# Altium schematic sheet 1.Engine.SchDoc
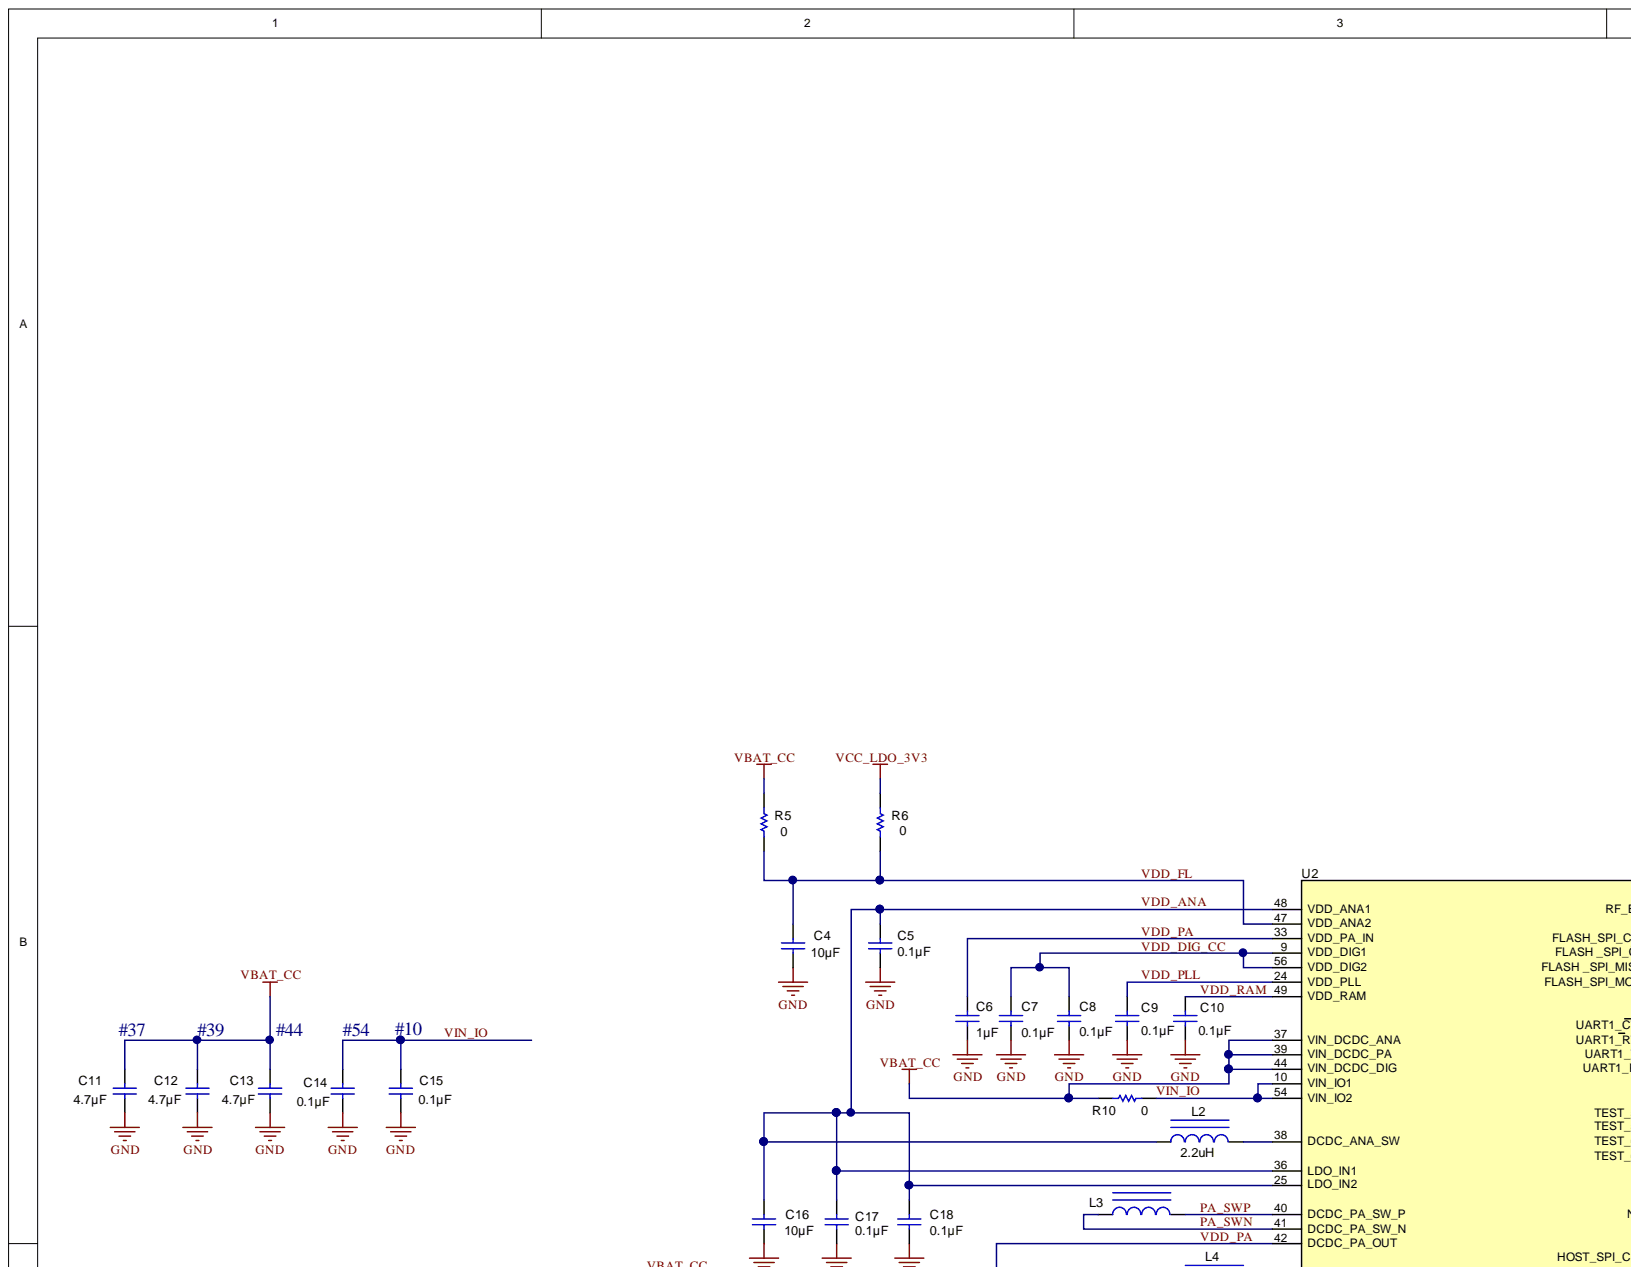
[diagram p0: 1.Engine.SchDoc, top left]
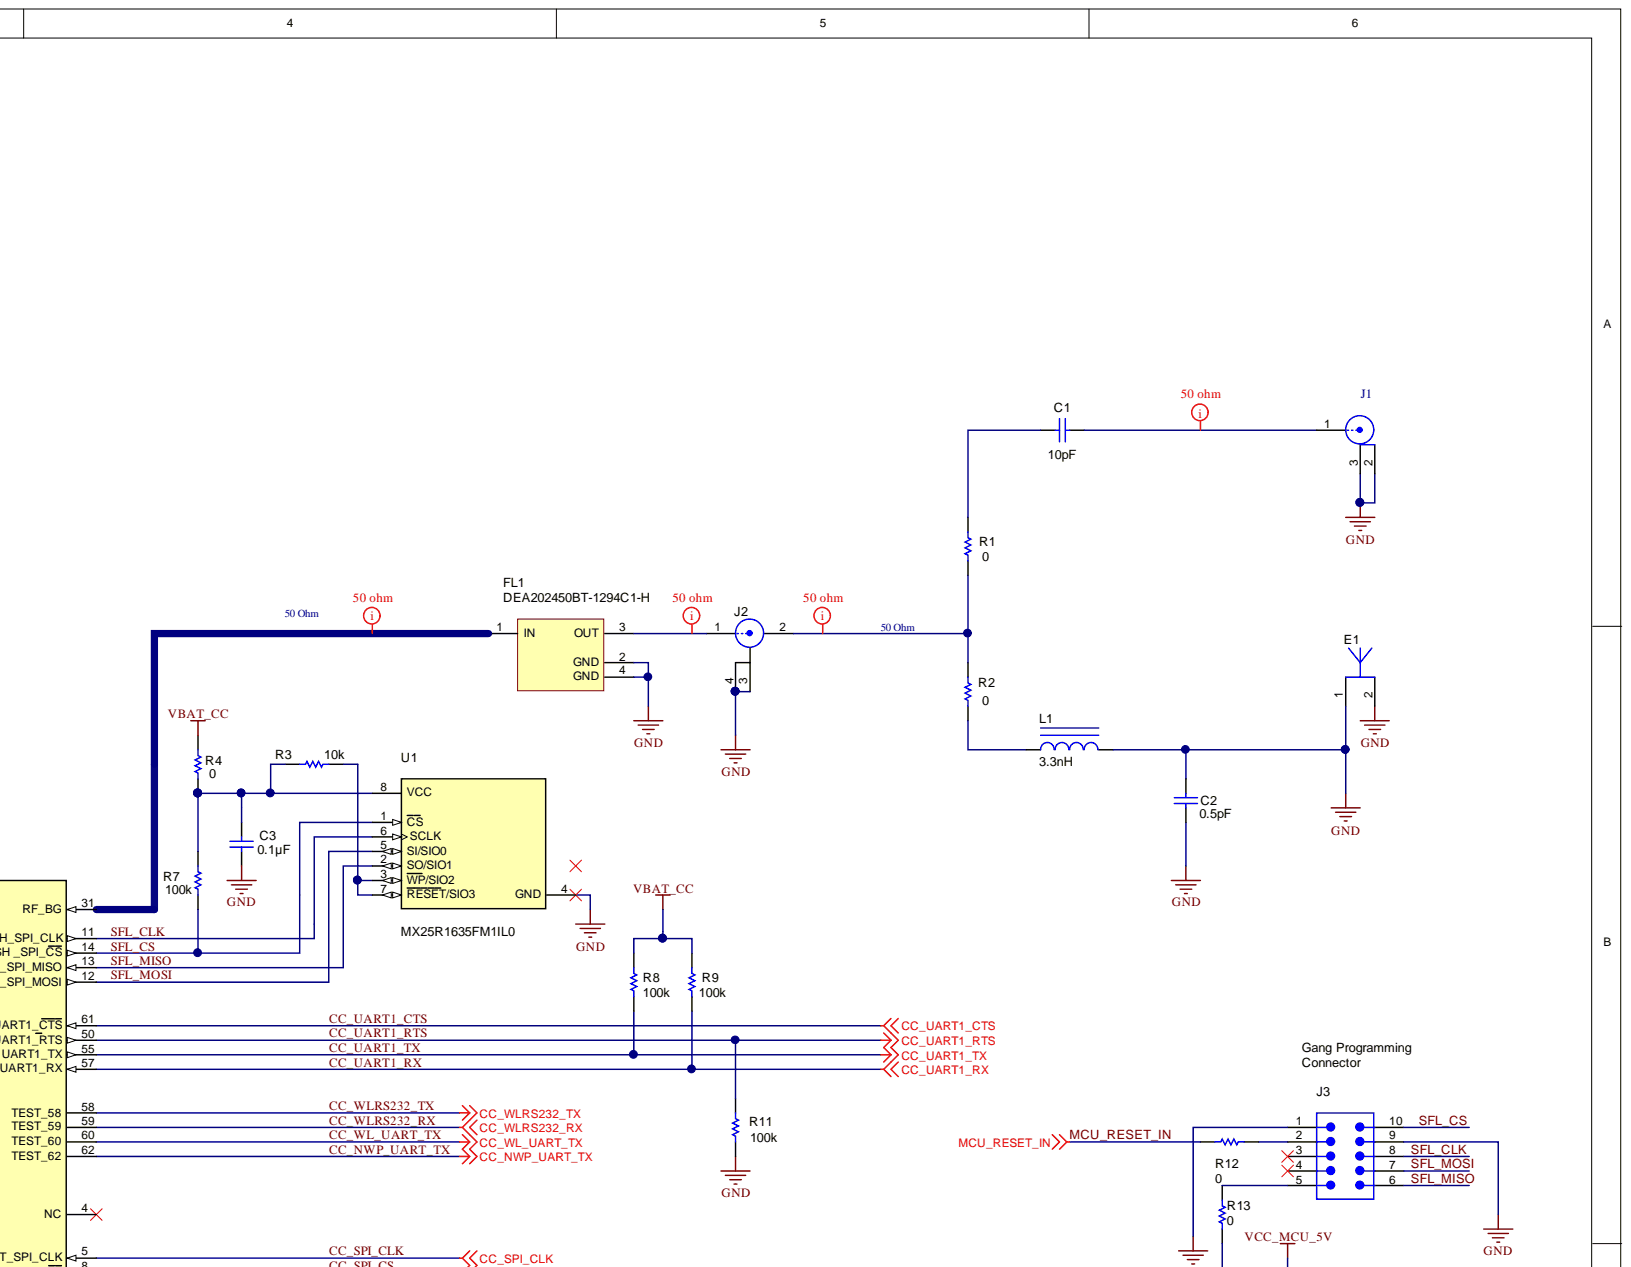
[diagram p1: 1.Engine.SchDoc, top right]
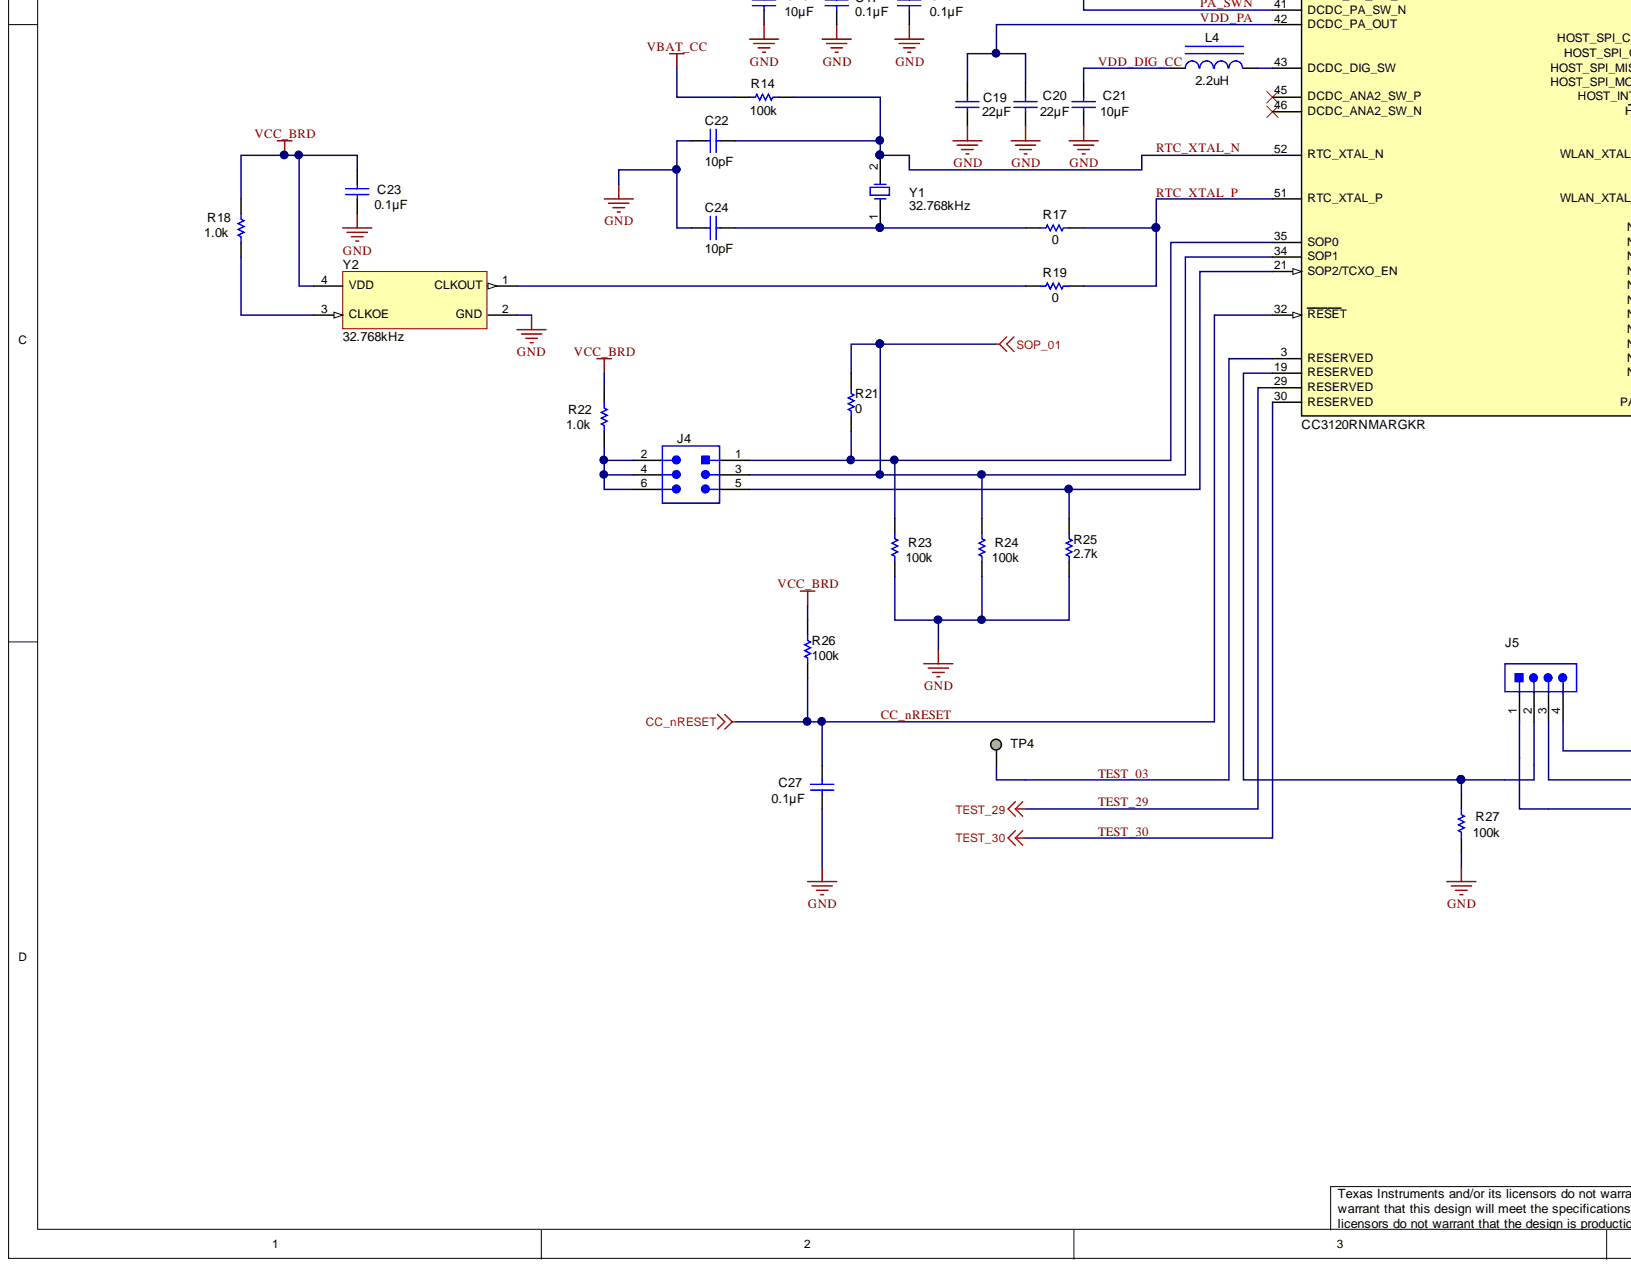
[diagram p2: 1.Engine.SchDoc, bottom left]
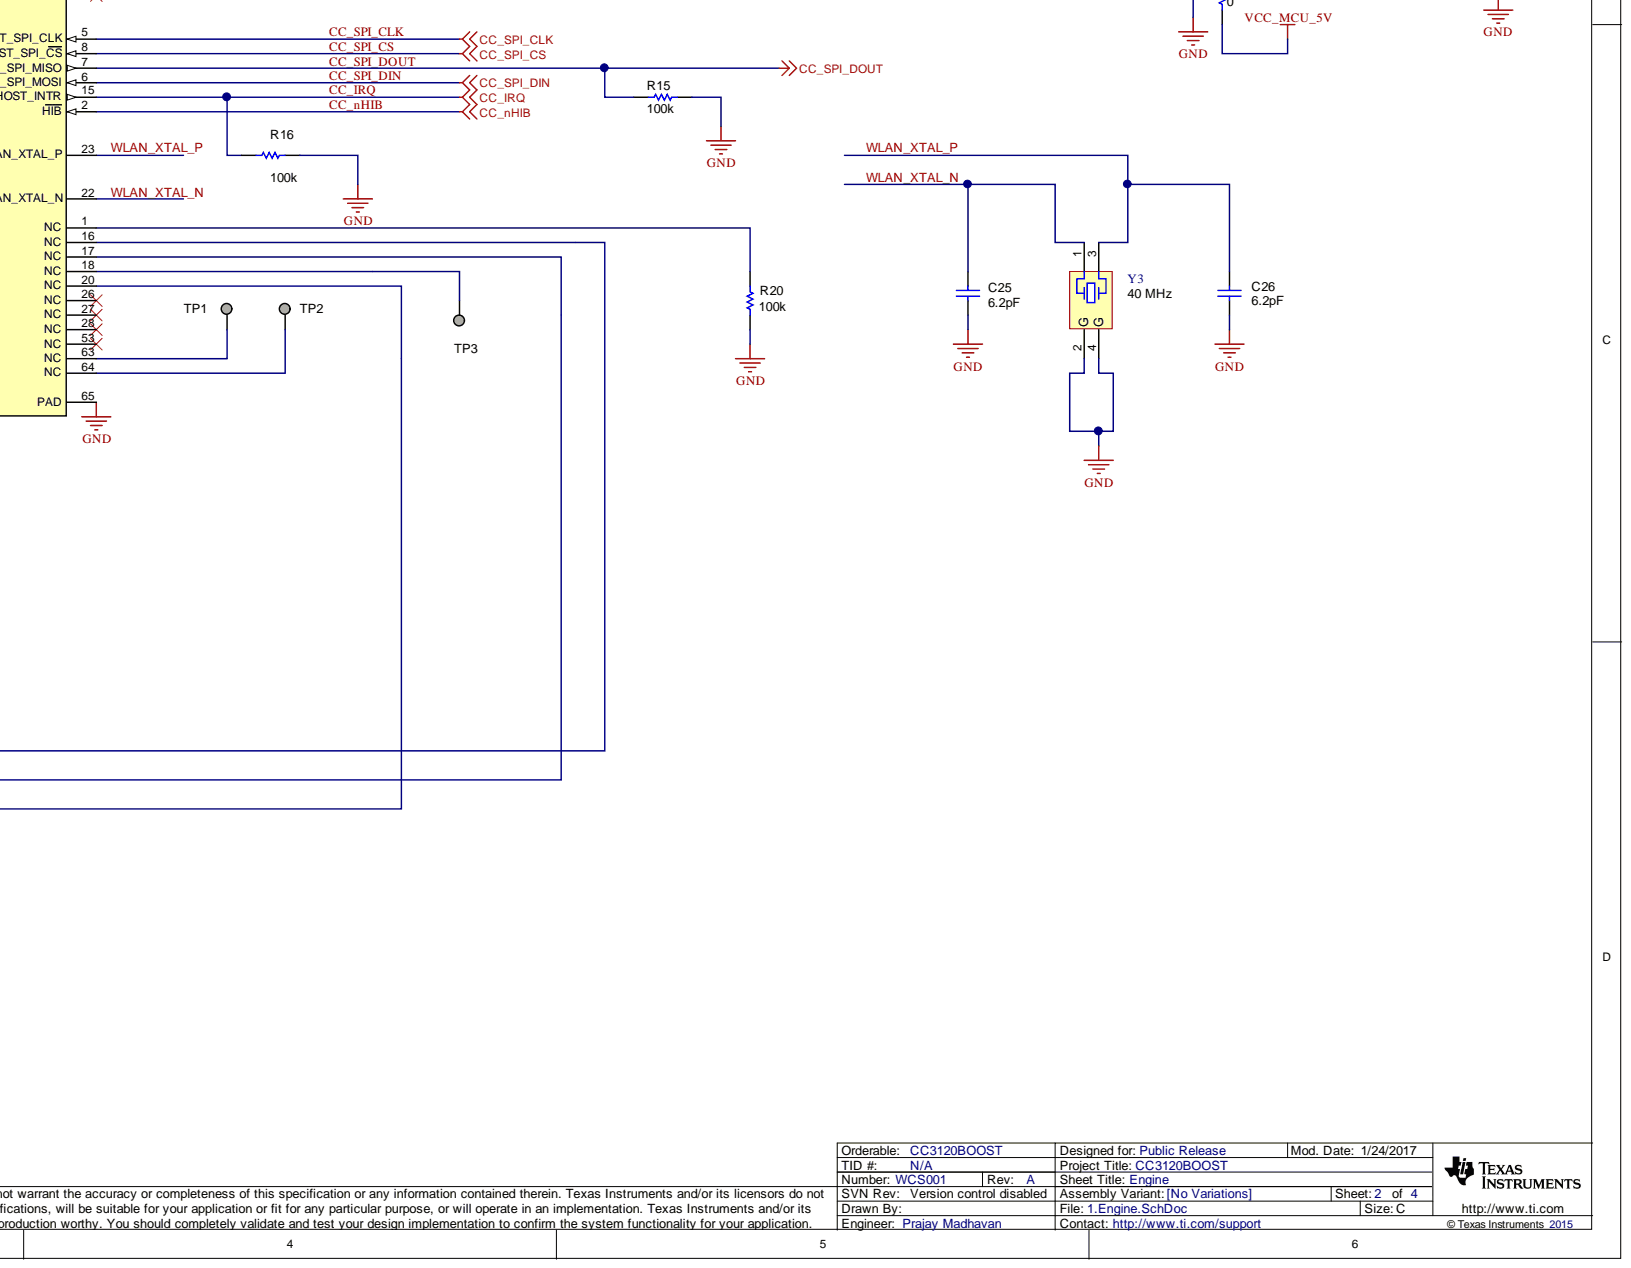
[diagram p3: 1.Engine.SchDoc, bottom right]

## Parts
C1  value 10pF  [0402]  (hidden comment: 500R07S100JV4T)
C2  value 0.5pF  [0402]  (hidden comment: GRM1555C1HR50BA01D)
C3  ValueDisplayed: 0.1µF  [0402]  (hidden comment: C1005X5R1A104K050BA)
C4  ValueDisplayed: 10µF  [0603]  (hidden comment: GRM188R60J106ME47D)
C5  ValueDisplayed: 0.1µF  [0402]  (hidden comment: C1005X5R1A104K050BA)
C6  ValueDisplayed: 1µF  [0402]  (hidden comment: C1005X5R1A105K050BB)
C7  ValueDisplayed: 0.1µF  [0402]  (hidden comment: C1005X5R1A104K050BA)
C8  ValueDisplayed: 0.1µF  [0402]  (hidden comment: C1005X5R1A104K050BA)
C9  ValueDisplayed: 0.1µF  [0402]  (hidden comment: C1005X5R1A104K050BA)
C10  ValueDisplayed: 0.1µF  [0402]  (hidden comment: C1005X5R1A104K050BA)
C11  ValueDisplayed: 4.7µF  [0402]  (hidden comment: C1005X5R0J475M050BC)
C12  ValueDisplayed: 4.7µF  [0402]  (hidden comment: C1005X5R0J475M050BC)
C13  ValueDisplayed: 4.7µF  [0402]  (hidden comment: C1005X5R0J475M050BC)
C14  ValueDisplayed: 0.1µF  [0402]  (hidden comment: C1005X5R1A104K050BA)
C15  ValueDisplayed: 0.1µF  [0402]  (hidden comment: C1005X5R1A104K050BA)
C16  ValueDisplayed: 10µF  [0603]  (hidden comment: GRM188R60J106ME47D)
C17  ValueDisplayed: 0.1µF  [0402]  (hidden comment: C1005X5R1A104K050BA)
C18  ValueDisplayed: 0.1µF  [0402]  (hidden comment: C1005X5R1A104K050BA)
C19  ValueDisplayed: 22µF  [0603]  (hidden comment: C1608X5R0G226M080AA)
C20  ValueDisplayed: 22µF  [0603]  (hidden comment: C1608X5R0G226M080AA)
C21  ValueDisplayed: 10µF  [0603]  (hidden comment: GRM188R60J106ME47D)
C22  value 10pF  [0402]  (hidden comment: 500R07S100JV4T)
C23  ValueDisplayed: 0.1µF  [0402]  (hidden comment: C1005X5R1A104K050BA)
C24  value 10pF  [0402]  (hidden comment: 500R07S100JV4T)
C25  value 6.2pF  [0402]  (hidden comment: GRM1555C1H6R2CA01D)
C26  value 6.2pF  [0402]  (hidden comment: GRM1555C1H6R2CA01D)
C27  ValueDisplayed: 0.1µF  [0402]  (hidden comment: C1005X5R1A104K050BA)
E1  AH316M245001-T  [TAYO_AH316M]  (value not drawn)
FL1  DEA202450BT-1294C1-H  [TDK_DEA202450BT-1294C1-H]
J1  U.FL-R-SMT-1  [CONN_UFL-R-SMT-1]  (value not drawn)
J2  MM8030-2610RJ3  [MuRata_MM8030-2610]  (value not drawn)
J3  TC2050-IDC-430-FP  [TC2050-IDC-430-FP]  (value not drawn)  (no BOM)
J4  GRPB032VWVN-RC  [Sullins_GRPB032VWVN-RC]  (value not drawn)
J5  GRPB041VWVN-RC  [Sullins_GRPB041VWVN-RC]  (value not drawn)
L1  3.3nH  [IND_LQG15H]
L2  value 2.2uH  [1008]  (hidden comment: LQM2HPN2R2MG0L)
L3  LQM2HPN1R0MG0L  [1008]  (value not drawn)
L4  value 2.2uH  [1008]  (hidden comment: LQM2HPN2R2MG0L)
R1  value 0  [0402]  (hidden comment: ERJ-2GE0R00X)
R2  value 0  [0402]  (hidden comment: ERJ-2GE0R00X)
R3  value 10k  [0402]  (hidden comment: CRCW040210K0JNED)
R4  value 0  [0603]  (hidden comment: RC0603JR-070RL)
R5  value 0  [0603]  (hidden comment: RC0603JR-070RL)
R6  value 0  [0603]  (hidden comment: RC0603JR-070RL)
R7  value 100k  [0402]  (hidden comment: CRCW0402100KJNED)
R8  value 100k  [0402]  (hidden comment: CRCW0402100KJNED)
R9  value 100k  [0402]  (hidden comment: CRCW0402100KJNED)
R10  value 0  [0603]  (hidden comment: RC0603JR-070RL)
R11  value 100k  [0402]  (hidden comment: CRCW0402100KJNED)
R12  value 0  [0402]  (hidden comment: ERJ-2GE0R00X)
R13  value 0  [0402]  (hidden comment: ERJ-2GE0R00X)
R14  value 100k  [0402]  (hidden comment: CRCW0402100KJNED)
R15  value 100k  [0402]  (hidden comment: CRCW0402100KJNED)
R16  value 100k  [0402]  (hidden comment: CRCW0402100KJNED)
R17  value 0  [0402]  (hidden comment: ERJ-2GE0R00X)
R18  value 1.0k  [0402]  (hidden comment: CRCW04021K00JNED)
R19  value 0  [0402]  (hidden comment: ERJ-2GE0R00X)
R20  value 100k  [0402]  (hidden comment: CRCW0402100KJNED)
R21  value 0  [0402]  (hidden comment: ERJ-2GE0R00X)
R22  value 1.0k  [0402]  (hidden comment: CRCW04021K00JNED)
R23  value 100k  [0402]  (hidden comment: CRCW0402100KJNED)
R24  value 100k  [0402]  (hidden comment: CRCW0402100KJNED)
R25  value 2.7k  [0402]  (hidden comment: CRCW04022K70JNED)
R26  value 100k  [0402]  (hidden comment: CRCW0402100KJNED)
R27  value 100k  [0402]  (hidden comment: CRCW0402100KJNED)
TP1  TESTPOINT  [TP_H045P075]  (value not drawn)  (no BOM)
TP2  TESTPOINT  [TP_H045P075]  (value not drawn)  (no BOM)
TP3  TESTPOINT  [TP_H045P075]  (value not drawn)  (no BOM)
TP4  TESTPOINT  [TP_H045P075]  (value not drawn)  (no BOM)
U1  MX25R1635FM1IL0  [SOP-8_150MIL]
U2  CC3120RNMARGKR  [RGK0064B]
Y1  Frequency: 32.768kHz  [XTAL_ABS07]  (hidden comment: ABS07-32.768KHZ-9-T)
Y2  Frequency: 32.768kHz  [MC_OV-7604-C7]  (hidden comment: OV-7604-C7)
Y3  Frequency: 40 MHz  [Epson_FA-20H]  (hidden comment: Q24FA20H0039600)

## Nets
GND: C2.1 C2.2 C3.2 C4.2 C5.2 C6.2 C7.2 C8.2 C9.2 C10.2 C11.2 C12.2 C13.2 C14.2 C15.2 C16.2 C17.2 C18.2 C19.2 C20.2 C21.2 C22.2 C23.2 C24.2 C25.2 C26.2 C27.2 E1.1 E1.2 FL1.2(GND) FL1.4(GND) J1.2 J1.3 J2.3 J2.4 J3.1 J3.9 L1.2 R11.2 R15.2 R16.2 R20.2 R23.2 R24.2 R25.2 R27.2 U1.4(GND) U2.65(PAD) Y2.2(GND) Y3.2(G) Y3.4(G)
SOP_01: J4.3 R21.2 R24.1 U2.34(SOP1)
VBAT_CC: C11.1 C12.1 C13.1 R4.2 R5.2 R8.1 R9.1 R10.2 R14.1 U2.37(VIN_DCDC_ANA) U2.39(VIN_DCDC_PA) U2.44(VIN_DCDC_DIG)
VCC_BRD: C23.1 R18.1 R22.1 R26.1 Y2.4(VDD)
VCC_LDO_3V3: R6.2
VCC_MCU_5V: R13.1
CC_IRQ: R16.1 U2.15(HOST_INTR)
CC_NHIB: U2.2(HIB)
CC_NRESET: C27.1 R26.2 U2.32(RESET)
CC_NWP_UART_TX: U2.62(TEST_62)
CC_SPI_CLK: U2.5(HOST_SPI_CLK)
CC_SPI_CS: U2.8(HOST_SPI_CS)
CC_SPI_DIN: U2.6(HOST_SPI_MOSI)
CC_SPI_DOUT: R15.1 U2.7(HOST_SPI_MISO)
CC_UART1_CTS: U2.61(UART1_CTS)
CC_UART1_RTS: R11.1 U2.50(UART1_RTS)
CC_UART1_RX: R9.2 U2.57(UART1_RX)
CC_UART1_TX: R8.2 U2.55(UART1_TX)
CC_WL_UART_TX: U2.60(TEST_60)
CC_WLRS232_RX: U2.59(TEST_59)
CC_WLRS232_TX: U2.58(TEST_58)
MCU_RESET_IN: R12.2
PA_SWN: L3.1 U2.41(DCDC_PA_SW_N)
PA_SWP: L3.2 U2.40(DCDC_PA_SW_P)
RTC_XTAL_N: C22.1 R14.2 U2.52(RTC_XTAL_N) Y1.2
RTC_XTAL_P: R17.2 R19.2 U2.51(RTC_XTAL_P)
SFL_CLK: J3.8 U1.6(SCLK) U2.11(FLASH_SPI_CLK)
SFL_CS: J3.10 R7.2 U1.1(CS) U2.14(FLASH__SPI_CS)
SFL_MISO: J3.6 U1.2(SO/SIO1) U2.13(FLASH__SPI_MISO)
SFL_MOSI: J3.7 U1.5(SI/SIO0) U2.12(FLASH_SPI_MOSI)
TEST_03: TP4.1 U2.3(RESERVED)
TEST_29: U2.29(RESERVED)
TEST_30: U2.30(RESERVED)
VDD_ANA: C5.1 C16.1 C17.1 C18.1 L2.1 U2.25(LDO_IN2) U2.36(LDO_IN1) U2.48(VDD_ANA1)
VDD_DIG_CC: C7.1 C8.1 C21.1 L4.1 U2.9(VDD_DIG1) U2.56(VDD_DIG2)
VDD_FL: C4.1 R5.1 R6.1 U2.47(VDD_ANA2)
VDD_PA: C6.1 C19.1 C20.1 U2.33(VDD_PA_IN) U2.42(DCDC_PA_OUT)
VDD_PLL: C9.1 U2.24(VDD_PLL)
VDD_RAM: C10.1 U2.49(VDD_RAM)
VIN_IO: C14.1 C15.1 R10.1 U2.10(VIN_IO1) U2.54(VIN_IO2)
WLAN_XTAL_N: C25.1 U2.22(WLAN_XTAL_N) Y3.1
WLAN_XTAL_P: C26.1 U2.23(WLAN_XTAL_P) Y3.3
NetC1_1: C1.1 R1.2
NetC1_2: C1.2 J1.1
NetC3_1: C3.1 R3.1 R4.1 R7.1 U1.8(VCC)
NetC24_1: C24.1 R17.1 Y1.1
NetFL1_1: FL1.1(IN) U2.31(RF_BG)
NetFL1_3: FL1.3(OUT) J2.1
NetJ2_2: J2.2 R1.1 R2.2
NetJ3_2: J3.2 R12.1
NetJ3_5: J3.5 R13.2
NetJ4_1: J4.1 R21.1 R23.1 U2.35(SOP0)
NetJ4_2: J4.2 J4.4 J4.6 R22.2
NetJ4_5: J4.5 R25.1 U2.21(SOP2/TCXO_EN)
NetJ5_1: J5.1 U2.20(NC)
NetJ5_2: J5.2 R27.1 U2.19(RESERVED)
NetJ5_3: J5.3 U2.17(NC)
NetJ5_4: J5.4 U2.16(NC)
NetL1_1: L1.1 R2.1
NetL2_2: L2.2 U2.38(DCDC_ANA_SW)
NetL4_2: L4.2 U2.43(DCDC_DIG_SW)
NetR3_2: R3.2 U1.3(WP/SIO2) U1.7(RESET/SIO3)
NetR18_2: R18.2 Y2.3(CLKOE)
NetR19_1: R19.1 Y2.1(CLKOUT)
NetR20_1: R20.1 U2.1(NC)
NetTP1_1: TP1.1 U2.63(NC)
NetTP2_1: TP2.1 U2.64(NC)
NetTP3_1: TP3.1 U2.18(NC)

Not connected: J3 3 4; U2 4(NC) 26(NC) 27(NC) 28(NC) 45(DCDC_ANA2_SW_P) 46(DCDC_ANA2_SW_N) 53(NC)

## Library data of the parts
C1 C22 C24 = 500R07S100JV4T: CAP, CERM, 10 pF, 50 V, +/- 5%, C0G/NP0, 0402; Manufacturer: Johanson Technology; Cap_F: 0.00000000001; CompType: Capacitor; Polarized: N; TaMax_C: 125; TaMin_C: -55; Tolerance_%: 5; U/M: EA; Value1: Unknown; Value2: 50V; VDC_V: 50; Cap_Case: 0402; Component Kind: Standard; ComponentLink1Description: Datasheet link; ComponentLink1URL: http://johansontechnology.com/images/stories/rfcaps/mlhqcaps/JTI_MLCC_HighQ_2011_14.pdf; LatestRevisionDate: 2015-02-23; Library Name: Capacitor_Ceramic.IntLib; MountType: SMT; PackageReference: 0402; PartNumber: 500R07S100JV4T; Published: 2008-06-19; RoHS: Y; Signal Integrity: Cap; Simulation: Cap; Supplier 1: Digi-Key; Supplier 2: Farnell; Supplier 3: Newark; Supplier Part Number 1: 712-1253-1-ND; Supplier Part Number 2: 1885333; Supplier Part Number 3: 97M6183; SymbolVersion: 2008-07-09; Technology: Ceramic; TempCo: C0G/NP0; ValueDisplayed: 10pF; Publisher: Texas Instruments
C2 = GRM1555C1HR50BA01D: CAP, CERM, 0.5 pF, 50 V, +/- 20%, C0G/NP0, 0402; Manufacturer: MuRata; Cap_F: 0.0000000000005; CompType: Capacitor; Polarized: N; TaMax_C: 125; TaMin_C: -55; Tolerance_%: 20; U/M: EA; Value1: Unknown; Value2: 50V; VDC_V: 50; Cap_Case: 0402; Component Kind: Standard; ComponentLink1Description: Datasheet link; ComponentLink1URL: http://www.murata.com/~/media/webrenewal/support/library/catalog/products/capacitor/mlcc/c02e.ashx; LatestRevisionDate: 2015-04-02; Library Name: Capacitor_Ceramic.IntLib; MountType: SMT; PackageReference: 0402; PartNumber: GRM1555C1HR50BA01D; Published: 2008-06-19; RoHS: Y; Signal Integrity: Cap; Simulation: Cap; Supplier 1: Digi-Key; Supplier 2: Mouser; Supplier Part Number 1: 490-6263-1-ND; Supplier Part Number 2: 81-GRM1555C1HR50BA1D; SymbolVersion: 2008-07-09; Technology: Ceramic; TempCo: C0G/NP0; ValueDisplayed: 0.5pF; Publisher: Texas Instruments
C3 C5 C7-C10 C14 C15 C17 C18 C23 C27 = C1005X5R1A104K050BA: CAP, CERM, 0.1 µF, 10 V, +/- 10%, X5R, 0402; Manufacturer: TDK; Cap_F: 0.0000001; CompType: Capacitor; Polarized: N; TaMax_C: 85; TaMin_C: -55; Tolerance_%: 10; U/M: EA; Value: 0.1uF; Value1: Unknown; Value2: 10V; Cap_Case: 0402; Component Kind: Standard; ComponentLink1Description: Datasheet link; ComponentLink1URL: http://product.tdk.com/en/catalog/datasheets/mlcc_commercial_general_en.pdf; LatestRevisionDate: 2015-02-23; Library Name: Capacitor_Ceramic.IntLib; MountType: SMT; PackageReference: 0402; PartNumber: C1005X5R1A104K050BA; Published: 2008-06-19; RoHS: Y; Signal Integrity: Cap; Simulation: Cap; Supplier 1: Digi-Key; Supplier 2: Mouser; Supplier 3: Farnell; Supplier Part Number 1: 445-1265-1-ND; Supplier Part Number 2: 810-C1005X5R1A104K; Supplier Part Number 3: 2368215; SymbolVersion: 2008-07-09; Technology: Ceramic; TempCo: X5R; VDC_V: 10; Publisher: Texas Instruments
C4 C16 C21 = GRM188R60J106ME47D: CAP, CERM, 10 µF, 6.3 V, +/- 20%, X5R, 0603; Manufacturer: MuRata; Cap_F: 0.00001; CompType: Capacitor; ESR_ohm: 0.009; IRMS_A: 2.74; Polarized: N; TaMax_C: 125; TaMin_C: -55; Tolerance_%: 20; U/M: EA; Value: 10uF; Value1: 0.009 ohm; Value2: 6.3V; VDC_V: 6.3; Cap_Case: 0603; Component Kind: Standard; ComponentLink1Description: Datasheet link; ComponentLink1URL: http://www.murata.com/products/capacitor/tech_data/pdf/grm188r60j106m.pdf; LatestRevisionDate: 2015-02-23; Library Name: Capacitor_Ceramic.IntLib; MountType: SMT; PackageReference: 0603; PartNumber: GRM188R60J106ME47D; Published: 2008-06-19; RoHS: Y; Signal Integrity: Cap; Simulation: Cap; Supplier 1: Digi-Key; Supplier 2: Mouser; Supplier 3: Farnell; Supplier Part Number 1: 490-3896-1-ND; Supplier Part Number 2: 81-GRM188R60J106ME47; Supplier Part Number 3: 1735528; SymbolVersion: 2008-07-09; Technology: Ceramic; TempCo: X5R; Publisher: Texas Instruments
C6 = C1005X5R1A105K050BB: CAP, CERM, 1 µF, 10 V, +/- 10%, X5R, 0402; Manufacturer: TDK; Cap_F: 0.000001; CompType: Capacitor; Polarized: N; TaMax_C: 85; TaMin_C: -55; Tolerance_%: 10; U/M: EA; Value: 1uF; Value1: Unknown; Value2: 10V; Cap_Case: 0402; Component Kind: Standard; ComponentLink1Description: Datasheet link; ComponentLink1URL: http://product.tdk.com/en/catalog/datasheets/mlcc_commercial_general_en.pdf; LatestRevisionDate: 2015-02-23; Library Name: Capacitor_Ceramic.IntLib; MountType: SMT; PackageReference: 0402; PartNumber: C1005X5R1A105K050BB; Published: 2008-06-19; RoHS: Y; Signal Integrity: Cap; Simulation: Cap; Supplier 1: Digi-Key; Supplier 2: Mouser; Supplier 3: Farnell; Supplier Part Number 1: 445-4114-1-ND; Supplier Part Number 2: 810-C1005X5R1A105K; Supplier Part Number 3: 2371912; SymbolVersion: 2008-07-09; Technology: Ceramic; TempCo: X5R; VDC_V: 10; Publisher: Texas Instruments
C11-C13 = C1005X5R0J475M050BC: CAP, CERM, 4.7 µF, 6.3 V, +/- 20%, X5R, 0402; Manufacturer: TDK; Cap_F: 0.0000047; CompType: Capacitor; Polarized: N; TaMax_C: 85; TaMin_C: -55; Tolerance_%: 20; U/M: EA; Value: 4.7uF; Value1: Unknown; Value2: 6.3V; VDC_V: 6.3; Cap_Case: 0402; Component Kind: Standard; ComponentLink1Description: Datasheet link; ComponentLink1URL: http://www.tdk.com/pdf/TDKMLCCCapRange.pdf; LatestRevisionDate: 2015-02-23; Library Name: Capacitor_Ceramic.IntLib; MountType: SMT; PackageReference: 0402; PartNumber: C1005X5R0J475M050BC; Published: 2008-06-19; RoHS: Y; Signal Integrity: Cap; Simulation: Cap; Supplier 1: Digi-Key; Supplier 2: Farnell; Supplier 3: Newark; Supplier Part Number 1: 445-7395-1-ND; Supplier Part Number 2: 1962109; Supplier Part Number 3: 48T2906; SymbolVersion: 2008-07-09; Technology: Ceramic; TempCo: X5R; Publisher: Texas Instruments
C19 C20 = C1608X5R0G226M080AA: CAP, CERM, 22 µF, 4 V, +/- 20%, X5R, 0603; Manufacturer: TDK; Cap_F: 0.000022; CompType: Capacitor; Polarized: N; TaMax_C: 85; TaMin_C: -55; Tolerance_%: 20; U/M: EA; Value: 22uF; Value1: Unknown; Value2: 4V; VDC_V: 4; Cap_Case: 0603; Component Kind: Standard; ComponentLink1Description: Datasheet link; ComponentLink1URL: http://www.tdk.com/pdf/TDKMLCCCapRange.pdf; LatestRevisionDate: 2015-02-23; Library Name: Capacitor_Ceramic.IntLib; MountType: SMT; PackageReference: 0603; PartNumber: C1608X5R0G226M080AA; Published: 2008-06-19; RoHS: Y; Signal Integrity: Cap; Simulation: Cap; Supplier 1: Digi-Key; Supplier Part Number 1: 445-8030-1-ND; SymbolVersion: 2008-07-09; Technology: Ceramic; TempCo: X5R; Publisher: Texas Instruments
C25 C26 = GRM1555C1H6R2CA01D: CAP, CERM, 6.2 pF, 50 V, +/- 5%, C0G/NP0, 0402; Manufacturer: MuRata; Cap_F: 0.0000000000062; CompType: Capacitor; Polarized: N; TaMax_C: 125; TaMin_C: -55; Tolerance_%: 5; U/M: EA; Value1: Unknown; Value2: 50V; VDC_V: 50; Cap_Case: 0402; Component Kind: Standard; ComponentLink1Description: Datasheet link; ComponentLink1URL: http://search.murata.co.jp/Ceramy/PnsearchViewAction.do?HnOFG=ON&HnNFG=ON&SEL_CNT=50&sLang=en&sKey=GRM1555C1H6R2CA01D&x=9&y=9&search=startwith; LatestRevisionDate: 2015-02-23; Library Name: Capacitor_Ceramic.IntLib; MountType: SMT; PackageReference: 0402; PartNumber: GRM1555C1H6R2CA01D; Published: 2008-06-19; RoHS: Y; Signal Integrity: Cap; Simulation: Cap; Supplier 1: Mouser; Supplier Part Number 1: 81-GRM1555C1H6R2CA1D; SymbolVersion: 2008-07-09; Technology: Ceramic; TempCo: C0G/NP0; ValueDisplayed: 6.2pF; Publisher: Texas Instruments
E1 = AH316M245001-T: ANT BLUETOOTH W-LAN ZIGBEE WIMAX, SMD; Manufacturer: Taiyo Yuden; CompType: Antenna; SymbolCreator: a0411947; Component Kind: Standard; ComponentLink1Description: Datasheet Link; ComponentLink1URL: http://www.yuden.co.jp/productdata/catalog/en/chipantenna01_e.pdf; LatestRevisionDate: 2015-02-19; Library Name: Other_Passive.IntLib; MountType: SMT; PackageReference: 3.2x1.6mm; PartNumber: AH316M245001-T; RoHS: Y; Supplier 1: Digi-Key; Supplier 2: Mouser; Supplier 3: Farnell; Supplier Part Number 1: 587-2200-1-ND; Supplier Part Number 2: 963-AH316M245001-T; Supplier Part Number 3: 2084742; SymbolVersion: 2014-04-04; Publisher: Texas Instruments
FL1 = DEA202450BT-1294C1-H: Multilayer Chip Band Pass Filter For 2.4GHz W-LAN/Bluetooth, SMD; Manufacturer: TDK; SymbolCreator: Altium; Value: 1.02 dB; Component Kind: Standard; ComponentLink1Description: Datasheet Link; ComponentLink1URL: http://product.tdk.com/en/catalog/datasheets/rf_bpf_dea202450bt-1294c1-h_en.pdf; CompType: Filter; LatestRevisionDate: 2015-02-19; Library Name: Other_Passive.IntLib; MountType: SMT; PackageReference: 2x1.25mm; PartNumber: DEA202450BT-1294C1-H; RoHS: Y; Supplier 1: Digi-Key; Supplier 2: Mouser; Supplier Part Number 1: 445-172335-1-ND; Supplier Part Number 2: 810-DEA202450BT1294C; SymbolVersion: 2015-01-04; Publisher: Texas Instruments
J1 = U.FL-R-SMT-1: Connector, Ultra-Mini Coaxial, SMD; Manufacturer: Hirose Electric Co. Ltd.; SymbolCreator: a0412235; U/M: EA; Component Kind: Standard; ComponentLink1Description: Datasheet Link; ComponentLink1URL: http://www.hirose.co.jp/cataloge_hp/e32119372.pdf; CompType: Connector; LatestRevisionDate: 2015-06-04; Library Name: Connectors_Other.IntLib; MountType: SMT; PackageReference: Ultra small CO-AX SMD; PartNumber: U.FL-R-SMT-1; RoHS: Y; Supplier 1: Digi-Key; Supplier 2: Mouser; Supplier 3: Farnell; Supplier Part Number 1: H11891CT-ND; Supplier Part Number 2: 798-UFLRSMT101; Supplier Part Number 3: 1688077; SymbolVersion: 2012-10-22; Publisher: Texas Instruments
J2 = MM8030-2610RJ3: Coaxial Connectors with Switch, 50 Ohm, SMD; Manufacturer: MuRata; SymbolCreator: Altium; U/M: EA; Component Kind: Standard; ComponentLink1Description: Datasheet Link; ComponentLink1URL: http://www.murata.com/~/media/webrenewal/support/library/catalog/products/switch/switch/o33e.ashx; CompType: Connector; LatestRevisionDate: 2015-02-17; Library Name: Connectors_Other.IntLib; MountType: SMT; PackageReference: Coaxial Connector with Switch; PartNumber: MM8030-2610RJ3; RoHS: Y; Supplier 1: Digi-Key; Supplier 2: Mouser; Supplier Part Number 1: 90-5907-1-ND; Supplier Part Number 2: 81-MM8030-2610RJ3; SymbolVerison: 2015-01-04; Publisher: Texas Instruments
J3 = TC2050-IDC-430-FP: Footprint for TC2050-IDC-430; Manufacturer: Tag-Connect; CompType: Receptacle; Note: Footprint only, to mate with Tag-Connect cable P/N TC2050-IDC-430; SymbolCreator: a0799628; U/M: EA; ComponentLink1Description: Datasheet Link; ComponentLink1URL: https://sps06.itg.ti.com/sites/KMS/crossdivteams/altium/docs/ComponentDatasheets/TC2050-IDC-430-NL.pdf; LatestRevisionDate: 2014-12-18; Library Name: Connectors_Other.IntLib; MountType: N/A; PackageReference: Footprint for TC2050-IDC-430 cable; PartNumber: TC2050-IDC-430-FP; RoHS: Y; SymbolVersion: 2013-06-14; Publisher: Texas Instruments
J4 = GRPB032VWVN-RC: Header, 50mil, 3x2, Gold, TH; Manufacturer: Sullins Connector Solutions; SymbolCreator: a0412235; U/M: EA; ClassName: Header; Component Kind: Standard; ComponentLink1Description: Datasheet Link; ComponentLink1URL: http://www.sullinscorp.com/drawings/82_GRPB___2VWVN-RC,_10954-D.pdf; CompType: Header; LatestRevisionDate: 2015-06-02; Library Name: Connectors_Sullins.IntLib; MountType: TH; PackageReference: Header, 3x2, 1.27mm, TH; PartNumber: GRPB032VWVN-RC; RoHS: Y; Supplier 1: Digi-Key; Supplier Part Number 1: S9015E-03-ND; SymbolVersion: 2013-10-14; Publisher: Texas Instruments
J5 = GRPB041VWVN-RC: Header, 50mil, 4x1, Gold, TH; Manufacturer: Sullins Connector Solutions; SymbolCreator: a0412235; U/M: EA; ClassName: Header; Component Kind: Standard; ComponentLink1Description: Datasheet Link; ComponentLink1URL: http://www.sullinscorp.com/drawings/71_GRPB___1VWVN-RC,_10957-C.pdf; CompType: Header; LatestRevisionDate: 2015-04-01; Library Name: Connectors_Sullins.IntLib; MountType: TH; PackageReference: TH, Header, 1.27 mm, 4x1, Straight; PartNumber: GRPB041VWVN-RC; RoHS: Y; Supplier 1: Digi-Key; Supplier Part Number 1: S9014E-04-ND; SymbolVersion: 2013-08-30; Publisher: Texas Instruments
L1 = LQG15HS3N3S02D: Inductor, Multilayer, Air Core, 3.3 nH, 0.3 A, 0.17 ohm, SMD; Manufacturer: MuRata; DCR_ohm: 0.17; Imax_A: 0.3; Ind_H: 3.3E-9; Irms: 0.3A @ C rise; Isat: 0.3A @ % inductance drop; Value2: 0.3A; Component Kind: Standard; ComponentLink1Description: Datasheet link; ComponentLink1URL: http://search.murata.co.jp/Ceramy/image/img/PDF/ENG/L0075S0083LQG15HS.pdf; CompType: Inductor; LatestRevisionDate: 2015-03-02; Library Name: Inductor_MuRata.IntLib; Material: Air Core; MountType: SMT; PackageReference: 0402 polarized; PartNumber: LQG15HS3N3S02D; Published: 2008-06-26; RoHS: Y; Signal Integrity: Inductor; Simulation: Inductor; Supplier 1: Digi-Key; Supplier 2: Mouser; Supplier Part Number 1: 490-2616-1-ND; Supplier Part Number 2: 81-LQG15HS3N3S02D; SymbolVersion: 2008-07-23; Technology: Multilayer; Value: 3.3nH; Value1: 0.17 ohm; ValueDisplayed: 3.3nH; Publisher: Texas Instruments
L2 L4 = LQM2HPN2R2MG0L: Inductor, Multilayer, Ferrite, 2.2 µH, 1.3 A, 0.08 ohm, SMD; Manufacturer: MuRata; DCR_ohm: 0.08; Imax_A: 1.3; Ind_H: 2.2E-6; Irms: 1.3A @ 40C rise; Value2: 1.3A; ValueDisplayed: 2.2µH; Component Kind: Standard; ComponentLink1Description: Datasheet link; ComponentLink1URL: http://psearch.murata.com/inductor/product/LQM2HPN2R2MG0%23.pdf; CompType: Inductor; LatestRevisionDate: 2014-11-24; Library Name: Inductor_MuRata.IntLib; Material: Ferrite; MountType: SMT; PackageReference: SMD, Body 2.5x2mm, Height 1.2mm; PartNumber: LQM2HPN2R2MG0L; Published: 2008-06-26; RoHS: Y; Signal Integrity: Inductor; Simulation: Inductor; Supplier 1: Digi-Key; Supplier 2: Mouser; Supplier Part Number 1: 490-5114-1-ND; Supplier Part Number 2: 81-LQM2HPN2R2MG0L; SymbolVersion: 2008-07-23; Technology: Multilayer; Value1: 0.08 ohm; Publisher: Texas Instruments
L3 = LQM2HPN1R0MG0L: Inductor, Multilayer, Ferrite, 1 µH, 1.6 A, 0.055 ohm, SMD; Manufacturer: MuRata; DCR_ohm: 0.055; Imax_A: 1.6; Ind_H: 1.0E-6; Irms: 1.6A @ 40C rise; Value: 1uH; Value2: 1.6A; ValueDisplayed: 1µH; Component Kind: Standard; ComponentLink1Description: Datasheet link; ComponentLink1URL: http://psearch.murata.com/inductor/product/LQM2HPN1R0MG0%23.pdf; CompType: Inductor; LatestRevisionDate: 2015-03-02; Library Name: Inductor_MuRata.IntLib; Material: Ferrite; MountType: SMT; PackageReference: SMD, Body 2.5x2mm, Height 1.2mm; PartNumber: LQM2HPN1R0MG0L; Published: 2008-06-26; RoHS: Y; Signal Integrity: Inductor; Simulation: Inductor; Supplier 1: Digi-Key; Supplier 2: Mouser; Supplier Part Number 1: 490-5112-1-ND; Supplier Part Number 2: 81-LQM2HPN1R0MG0L; SymbolVersion: 2008-07-23; Technology: Multilayer; Value1: 0.055 ohm; Publisher: Texas Instruments
R1 R2 R12 R13 R17 R19 R21 = ERJ-2GE0R00X: RES, 0, 5%, 0.063 W, 0402; Manufacturer: Panasonic; CompType: Resistor; EIA_Code: E24; Power_W: 0.063; TempCo: 600 ppm/C; Tolerance_%: 5; U/M: EA; Value2: 0.063W; Value_Code: 0000; VDC_V: 50; Component Kind: Standard; ComponentLink1Description: Datasheet link; ComponentLink1URL: http://www.panasonic.com/industrial/components/pdf/AOA0000CE1.pdf; LatestRevisionDate: 2015-02-16; Library Name: Resistor_0402.IntLib; MountType: SMT; PackageReference: 0402; PartNumber: ERJ-2GE0R00X; Published: 2008-06-26; Res_ohm: 0; RoHS: Y; Signal Integrity: Resistor; Simulation: Resistor; Supplier 1: Digi-Key; Supplier 2: Mouser; Supplier 3: Farnell; Supplier Part Number 1: P0.0JCT-ND; Supplier Part Number 2: 667-ERJ-2GE0R00X; Supplier Part Number 3: 2059190; SymbolVersion: 2009-05-29; Technology: Thick Film; Value1: 5%; ValueDisplayed: 0; Publisher: Texas Instruments
R3 = CRCW040210K0JNED: RES, 10 k, 5%, 0.063 W, 0402; Manufacturer: Vishay-Dale; CompType: Resistor; EIA_Code: E24; Power_W: 0.063; Res_ohm: 10000; TempCo: 200 ppm/C; Tolerance_%: 5; U/M: EA; Value2: 0.063W; Value_Code: 10k0; VDC_V: 50; Component Kind: Standard; ComponentLink1Description: Datasheet link; ComponentLink1URL: http://www.vishay.com/docs/20035/dcrcwe3.pdf; LatestRevisionDate: 2015-02-16; Library Name: Resistor_0402.IntLib; MountType: SMT; PackageReference: 0402; PartNumber: CRCW040210K0JNED; Published: 2008-06-26; RoHS: Y; Signal Integrity: Resistor; Simulation: Resistor; Supplier 1: Digi-Key; Supplier 2: Mouser; Supplier 3: Farnell; Supplier Part Number 1: 541-10KJCT-ND; Supplier Part Number 2: 71-CRCW0402J-10K-E3; Supplier Part Number 3: 1514663; SymbolVersion: 2009-05-29; Technology: Thick Film; Value1: 5%; ValueDisplayed: 10k; Publisher: Texas Instruments
R4-R6 R10 = RC0603JR-070RL: RES, 0, 5%, 0.1 W, 0603; Manufacturer: Yageo America; CompType: Resistor; EIA_Code: E24; Power_W: 0.1; TempCo: 200 ppm/C; Tolerance_%: 5; U/M: EA; Value2: 0.1W; Value_Code: 0000; VDC_V: 50; Component Kind: Standard; ComponentLink1Description: Datasheet link; ComponentLink1URL: http://www.yageo.com/pdf/Pu-RC0603_51_PbFree_L_2.pdf; LatestRevisionDate: 2014-12-16; Library Name: Resistor_0603.IntLib; MountType: SMT; PackageReference: 0603; PartNumber: RC0603JR-070RL; Published: 2008-06-26; Res_ohm: 0; RoHS: Y; Signal Integrity: Resistor; Simulation: Resistor; Supplier 1: Digi-Key; Supplier 2: Mouser; Supplier 3: Farnell; Supplier Part Number 1: 311-0.0GRCT-ND; Supplier Part Number 2: 603-RC0603JR-070RL; Supplier Part Number 3: 9233130; SymbolVersion: 2009-05-29; Technology: Thick Film; Value1: 5%; ValueDisplayed: 0; Publisher: Texas Instruments
R7-R9 R11 R14-R16 R20 R23 R24 R26 R27 = CRCW0402100KJNED: RES, 100 k, 5%, 0.063 W, 0402; Manufacturer: Vishay-Dale; CompType: Resistor; EIA_Code: E24; Power_W: 0.063; Res_ohm: 100000; TempCo: 200 ppm/C; Tolerance_%: 5; U/M: EA; Value2: 0.063W; VDC_V: 50; Component Kind: Standard; ComponentLink1Description: Datasheet link; ComponentLink1URL: http://www.vishay.com/docs/20035/dcrcwe3.pdf; LatestRevisionDate: 2015-02-16; Library Name: Resistor_0402.IntLib; MountType: SMT; PackageReference: 0402; PartNumber: CRCW0402100KJNED; Published: 2008-06-26; RoHS: Y; Signal Integrity: Resistor; Simulation: Resistor; Supplier 1: Digi-Key; Supplier 2: Mouser; Supplier 3: Farnell; Supplier Part Number 1: 541-100KJCT-ND; Supplier Part Number 2: 71-CRCW0402J-100K-E3; Supplier Part Number 3: 1652738; SymbolVersion: 2009-05-29; Technology: Thick Film; Value1: 5%; Value_Code: 100k; ValueDisplayed: 100k; Publisher: Texas Instruments
R18 R22 = CRCW04021K00JNED: RES, 1.0 k, 5%, 0.063 W, 0402; Manufacturer: Vishay-Dale; CompType: Resistor; EIA_Code: E24; Power_W: 0.063; Res_ohm: 1000; TempCo: 200 ppm/C; Tolerance_%: 5; U/M: EA; Value2: 0.063W; Value_Code: 1k00; VDC_V: 50; Component Kind: Standard; ComponentLink1Description: Datasheet link; ComponentLink1URL: http://www.vishay.com/docs/20035/dcrcwe3.pdf; LatestRevisionDate: 2015-02-16; Library Name: Resistor_0402.IntLib; MountType: SMT; PackageReference: 0402; PartNumber: CRCW04021K00JNED; Published: 2008-06-26; RoHS: Y; Signal Integrity: Resistor; Simulation: Resistor; Supplier 1: Digi-Key; Supplier 2: Mouser; Supplier 3: Farnell; Supplier Part Number 1: 541-1.0KJCT-ND; Supplier Part Number 2: 71-CRCW0402J-1.0K-E3; Supplier Part Number 3: 1514656; SymbolVersion: 2009-05-29; Technology: Thick Film; Value1: 5%; ValueDisplayed: 1.0k; Publisher: Texas Instruments
R25 = CRCW04022K70JNED: RES, 2.7 k, 5%, 0.063 W, 0402; Manufacturer: Vishay-Dale; AEC Category: Q200; CompType: Resistor; EIA_Code: E24; Power_W: 0.063; Res_ohm: 2700; SizeArea_mm2: 0.5; SizeX_mm: 1; SizeZ_mm: 0.6; TempCo: 200 ppm/C; Tolerance_%: 5; U/M: EA; Value2: 0.063W; Value_Code: 2k70; VDC_V: 50; AEC Qualified: ?; Component Kind: Standard; ComponentLink1Description: Datasheet link; ComponentLink1URL: http://www.vishay.com/docs/20035/dcrcwe3.pdf; LatestRevisionDate: 2016-12-01; Library Name: Resistor_0402.IntLib; MountType: SMT; PackageReference: 0402; PartNumber: CRCW04022K70JNED; Published: 2008-06-26; RoHS: Y; Signal Integrity: Resistor; Simulation: Resistor; SizeY_mm: 0.5; Supplier 1: Digi-Key; Supplier 2: Mouser; Supplier 3: Farnell; Supplier Part Number 1: 541-2.7KJCT-ND; Supplier Part Number 2: 71-CRCW0402J-2.7K-E3; Supplier Part Number 3: 1563903; SymbolVersion: 2009-05-29; Technology: Thick Film; Value1: 5%; ValueDisplayed: 2.7k; Publisher: Texas Instruments
TP1-TP4 = TP_H0.45P0.75: Manufacturer: Texas Instruments; CompType: Pad; ComponentLink1Description: Datasheet Link; ComponentLink1URL: https://sps06.itg.ti.com/sites/KMS/crossdivteams/altium/docs/ComponentDatasheets/testpin with 750um Padsice and 450um drillhole.pdf; Description: Testpoint; LatestRevisionDate: 2015-08-27; Library Name: Hardware.IntLib; MountType: TH; PackageReference: Test Point, 0.45mm hole size; PartNumber: TP_H0.45P0.75; SymbolVersion: 2010-10-20; Publisher: Texas Instruments
U1 = MX25R1635FM1IL0: ULTRA LOW POWER, 16M-BIT [x 1/x 2/x 4] CMOS MXSMIO(SERIAL MULTI I/O) FLASH MEMORY, SOP-8; Manufacturer: Macronix International Co., LTD; SymbolCreator: Altium; Component Kind: Standard; ComponentLink1Description: Datasheet Link; ComponentLink1URL: http://www.macronix.com/Lists/Datasheet/Attachments/3270/MX25R1635F,%20Wide%20Range,%2016Mb,%20v1.2.pdf; LatestRevisionDate: 2015-12-03; Library Name: Other_IC.IntLib; MountType: SMT; PackageReference: 8-SOP; PartNumber: MX25R1635FM1IL0; RoHS: Y; SymbolVersion: 2015-12-03; Publisher: Texas Instruments
U2 = CC3120RNMARGKR: SimpleLink Wi-Fi Network Processor, Internet-of-Things Solution for MCU Applications, RGK0064B (VQFN-64); Alternate Manufacturer: Texas Instruments; Alternate PartNumber: CC3120RNMARGKT; CompType: IC; SizeArea_mm2: 81; SizeAreaWB_mm2: 121; SizeX_mm: 9; SizeZ_mm: 1; SymbolCreator: Altium; U/M: EA; Component Kind: Standard; ComponentLink1Description: Datasheet Link; ComponentLink1URL: https://sps06.itg.ti.com/sites/KMS/crossdivteams/altium/docs/ComponentDatasheets/CC3120_Advanced_Information_July2015.pdf; LatestRevisionDate: 2016-11-18; Manufacturer: Texas Instruments; MountType: SMT; PackageDescription: VQFN-64; PackageReference: RGK0064B; PartNumber: CC3120RNMARGKR; SizeY_mm: 9; SymbolVersion: 2015-09-04; Library Name: TI_Pre_RTM.IntLib; Publisher: Texas Instruments
Y1 = ABS07-32.768KHZ-9-T: CRYSTAL, 32.768KHZ, 9PF, SMD; Manufacturer: Abracon Corportation; Frequency Stability: ±20ppm; SymbolCreator: a0412235; TaMax_C: 85; TaMin_C: -40; U/M: EA; Component Kind: Standard; ComponentLink1Description: Datasheet Link; ComponentLink1URL: http://www.abracon.com/Resonators/ABS07.pdf; CompType: Crystal; LatestRevisionDate: 2015-02-16; Library Name: Oscillators.IntLib; MountType: SMT; PackageReference: 3.2x0.9x1.5mm; PartNumber: ABS07-32.768KHZ-9-T; RoHS: Y; Supplier 1: Digi-Key; Supplier 2: Mouser; Supplier 3: Farnell; Supplier Part Number 1: 535-9544-1-ND; Supplier Part Number 2: 815-ABS07-32.768K9T; Supplier Part Number 3: 2101346; SymbolVersion: 2008-07-09; Publisher: Texas Instruments
Y2 = OV-7604-C7: Low Power Clock Oscillator, 32.768 kHz, SMD; Manufacturer: Micro Crystal AG; SymbolCreator: a0412235; TaMax_C: 85; TaMin_C: -40; U/M: EA; Component Kind: Standard; ComponentLink1Description: Datasheet Link; ComponentLink1URL: http://www.microcrystal.com/images/_PDF/4_Low-power-Oscillator_OV-WM/ov-7604-c7.pdf; CompType: Oscillator; LatestRevisionDate: 2015-03-19; Library Name: Oscillators.IntLib; MountType: SMT; PackageReference: OSC, 1.5x1x3.2mm; PartNumber: OV-7604-C7; RoHS: Y; Supplier 1: Farnell; Supplier 2: Newark; Supplier Part Number 1: 1539387; Supplier Part Number 2: 94M8600; SymbolVersion: 2014-04-03; Publisher: Texas Instruments
Y3 = Q24FA20H0039600: Crystal, 40MHz, 8pF, SMD; Manufacturer: Epson; Frequency Stability: ±7ppm; SymbolCreator: a0412235; TaMax_C: 85; TaMin_C: -30; U/M: EA; Component Kind: Standard; ComponentLink1Description: Datasheet Link; ComponentLink1URL: http://www.eea.epson.com/portal/pls/portal/docs/1/1729473.PDF; CompType: Crystal; LatestRevisionDate: 2015-06-08; Library Name: Oscillators.IntLib; MountType: SMT; PackageReference: 2.5x2mm; PartNumber: Q24FA20H0039600; RoHS: Y; SymbolVersion: 2011-08-23; Publisher: Texas Instruments
